# Altium schematic sheet TKZDB.SchDoc
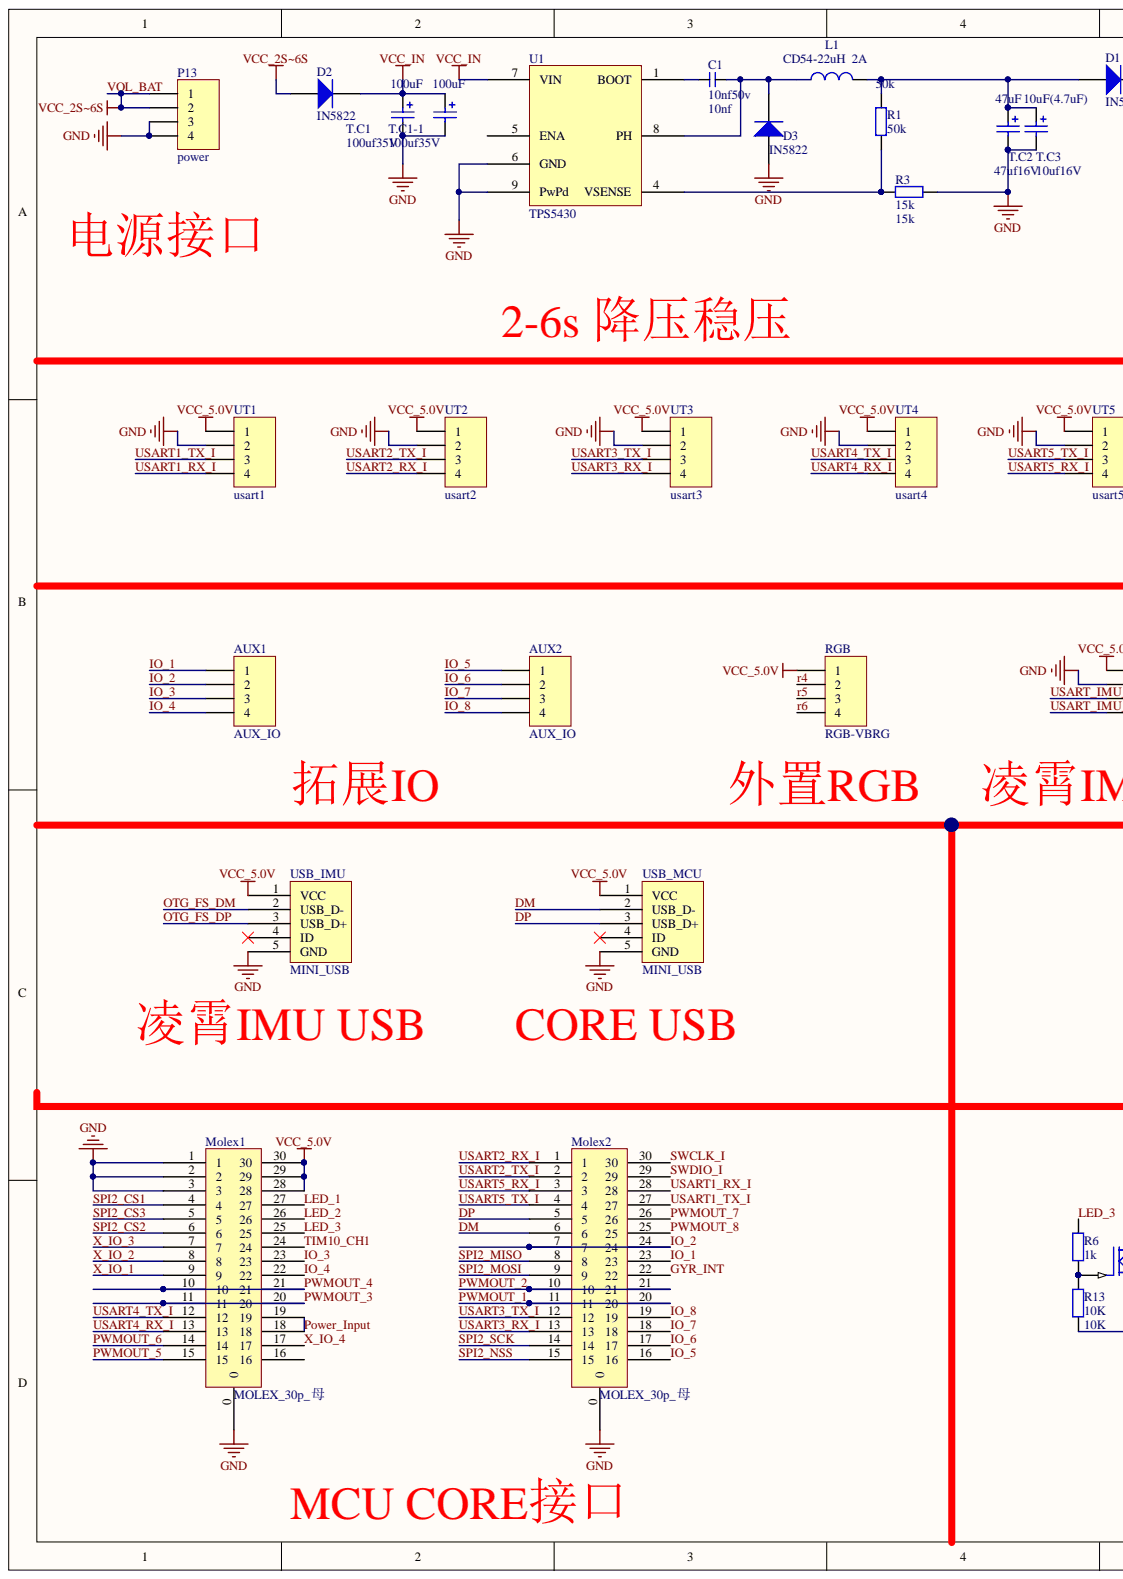
[diagram p0: TKZDB.SchDoc, left]
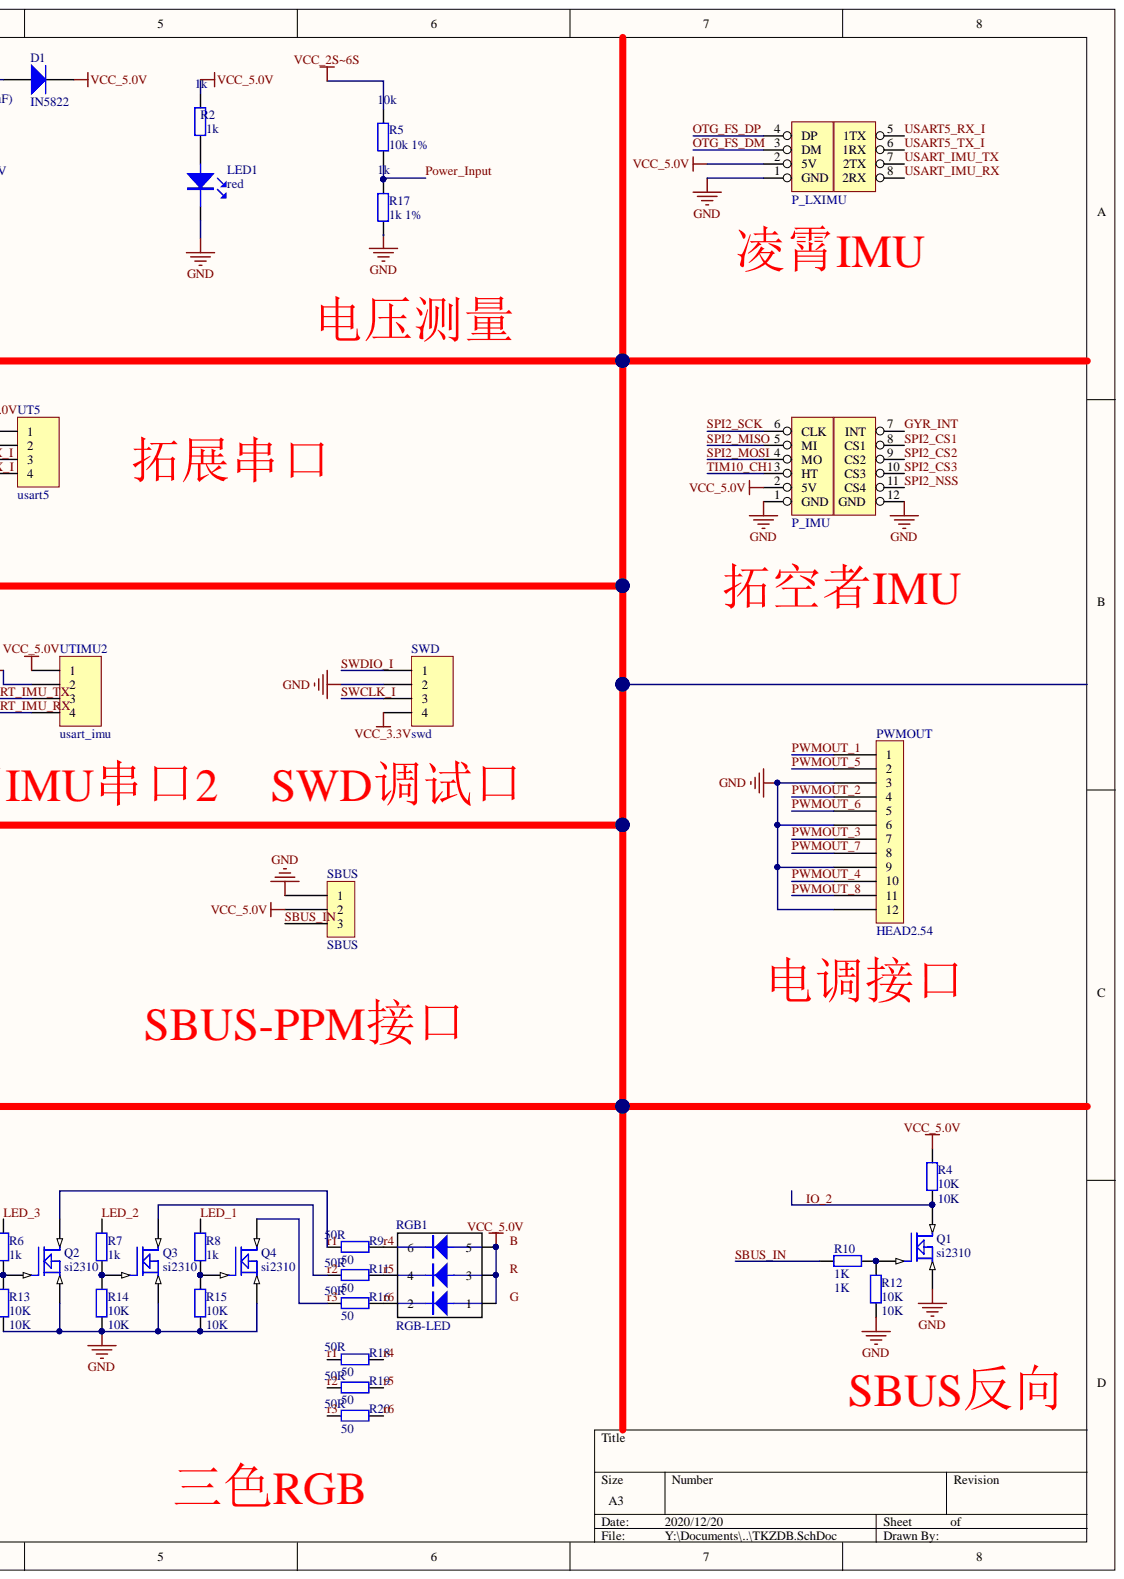
[diagram p1: TKZDB.SchDoc, right]

## Parts
AUX1  AUX_IO  [SH_1.0_卧]
AUX2  AUX_IO  [SH_1.0_卧]
C1  10nf50v  value 10nf  [0402_small]
D1  IN5822  [SOD123]
D2  IN5822  [SOD123]
D3  IN5822  [SOD123]
L1  CD54‐22uH  2A  [L-8X7mm-S]
LED1  red  [0402_small]
Molex1  MOLEX_30p_母  [MOLEX_30p_母]
Molex2  MOLEX_30p_母  [MOLEX_30p_母]
P13  power  [SH_1.0_卧]
P_IMU  IMU_TKZ  [IMU_TKZ_SM2]  (value not drawn)
P_LXIMU  IMU_LX  [IMU-LX-SM2]  (value not drawn)
PWMOUT  HEAD2.54  [HEAD1*3*4W]
Q1  si2310  [SOT-23]
Q2  si2310  [SOT-23]
Q3  si2310  [SOT-23]
Q4  si2310  [SOT-23]
R1  50k  [0402_small]
R2  1k  [0402_small]
R3  15k  [0402_small]
R4  10K  [0402_small]
R5  10k 1%  value 10k  [0402_small]
R6  1k  [0402_small]
R7  1k  [0402_small]
R8  1k  [0402_small]
R9  50  value 50R  [0402_small]
R10  1K  [0402_small]
R11  50  value 50R  [0402_small]
R12  10K  [0402_small]
R13  10K  [0402_small]
R14  10K  [0402_small]
R15  10K  [0402_small]
R16  50  value 50R  [0402_small]
R17  1k 1%  value 1k  [0402_small]
R18  50  value 50R  [0402_small]
R19  50  value 50R  [0402_small]
R20  50  value 50R  [0402_small]
RGB  RGB-VBRG  [SH_1.0_卧]
RGB1  RGB-LED  [zhuixin_5050RGB]
SBUS  SBUS  [SH_1.0_3P卧]
SWD  swd  [SH_1.0_卧]
T.C1  100uf35V  value 100uF  [TAN-C(6032-28)]
T.C1-1  100uf35V  value 100uF  [TAN-C(6032-28)]
T.C2  47uf16V  value 47uF  [TAN-B(3528-21)]
T.C3  10uf16V  value 10uF(4.7uF)  [TAN-A(3216-18)]
U1  TPS5430  [R-PDSO-G8]
USB_IMU  MINI_USB  [MICROUSB2]
USB_MCU  MINI_USB  [MICROUSB2]
UT1  usart1  [SH_1.0_卧]
UT2  usart2  [SH_1.0_卧]
UT3  usart3  [SH_1.0_卧]
UT4  usart4  [SH_1.0_卧]
UT5  usart5  [SH_1.0_卧]
UTIMU2  usart_imu  [SH_1.0_卧]

## Nets
GND: D3.1 LED1.2 Molex1.0 Molex1.1 Molex1.2 Molex1.3 Molex2.0 P13.3 P13.4 P_IMU.1(GND) P_IMU.12(GND) P_LXIMU.1(GND) PWMOUT.3 PWMOUT.6 PWMOUT.9 PWMOUT.12 Q1.2 Q2.2 Q3.2 Q4.2 R3.2 R12.1 R13.1 R14.1 R15.1 R17.1 SBUS.1 SWD.2 T.C1.2 T.C1-1.2 T.C2.2 T.C3.2 U1.6(GND) U1.9(PwPd) USB_IMU.5(GND) USB_MCU.5(GND) UT1.2 UT2.2 UT3.2 UT4.2 UT5.2 UTIMU2.2
VCC_3.3V: SWD.4
VCC_5.0V: D1.2 Molex1.28 Molex1.29 Molex1.30 P_IMU.2(5V) P_LXIMU.2(5V) R2.2 R4.2 RGB.1 RGB1.1 RGB1.3 RGB1.5 SBUS.2 USB_IMU.1(VCC) USB_MCU.1(VCC) UT1.1 UT2.1 UT3.1 UT4.1 UT5.1 UTIMU2.1
VCC_IN: D2.2 T.C1.1 T.C1-1.1 U1.7(VIN)
DM: Molex2.6 USB_MCU.2(USB_D-)
DP: Molex2.5 USB_MCU.3(USB_D+)
GYR_INT: Molex2.22 P_IMU.7(INT)
IO_1: AUX1.1 Molex2.23
IO_2: AUX1.2 Molex2.7 Molex2.24 Q1.3 R4.1
IO_3: AUX1.3 Molex1.23
IO_4: AUX1.4 Molex1.22
IO_5: AUX2.1 Molex2.16
IO_6: AUX2.2 Molex2.17
IO_7: AUX2.3 Molex2.18
IO_8: AUX2.4 Molex2.19
LED_1: Molex1.27 R8.1
LED_2: Molex1.26 R7.1
LED_3: Molex1.25 R6.2
OTG_FS_DM: P_LXIMU.3(DM) USB_IMU.2(USB_D-)
OTG_FS_DP: P_LXIMU.4(DP) USB_IMU.3(USB_D+)
Power_Input: Molex1.18 Molex1.19 R5.1 R17.2
PWMOUT_1: Molex2.11 Molex2.20 PWMOUT.1
PWMOUT_2: Molex2.10 Molex2.21 PWMOUT.4
PWMOUT_3: Molex1.11 Molex1.20 PWMOUT.7
PWMOUT_4: Molex1.10 Molex1.21 PWMOUT.10
PWMOUT_5: Molex1.15 PWMOUT.2
PWMOUT_6: Molex1.14 PWMOUT.5
PWMOUT_7: Molex2.26 PWMOUT.8
PWMOUT_8: Molex2.25 PWMOUT.11
r1: Q2.3 R9.2 R18.2
r2: Q3.3 R11.2 R19.2
r3: Q4.3 R16.2 R20.2
r4: R9.1 R18.1 RGB.2 RGB1.6
r5: R11.1 R19.1 RGB.3 RGB1.4
r6: R16.1 R20.1 RGB.4 RGB1.2
SBUS_IN: R10.1 SBUS.3
SPI2_CS1: Molex1.4 P_IMU.8(CS1)
SPI2_CS2: Molex1.6 P_IMU.9(CS2)
SPI2_CS3: Molex1.5 P_IMU.10(CS3)
SPI2_MISO: Molex2.8 P_IMU.5(MI)
SPI2_MOSI: Molex2.9 P_IMU.4(MO)
SPI2_NSS: Molex2.15 P_IMU.11(CS4)
SPI2_SCK: Molex2.14 P_IMU.6(CLK)
SWCLK_I: Molex2.30 SWD.3
SWDIO_I: Molex2.29 SWD.1
TIM10_CH1: Molex1.24 P_IMU.3(HT)
USART1_RX_I: Molex2.28 UT1.4
USART1_TX_I: Molex2.27 UT1.3
USART2_RX_I: Molex2.1 UT2.4
USART2_TX_I: Molex2.2 UT2.3
USART3_RX_I: Molex2.13 UT3.4
USART3_TX_I: Molex2.12 UT3.3
USART4_RX_I: Molex1.13 UT4.4
USART4_TX_I: Molex1.12 UT4.3
USART5_RX_I: Molex2.3 P_LXIMU.5(1TX) UT5.4
USART5_TX_I: Molex2.4 P_LXIMU.6(1RX) UT5.3
USART_IMU_RX: P_LXIMU.8(2RX) UTIMU2.4
USART_IMU_TX: P_LXIMU.7(2TX) UTIMU2.3
VOL_BAT: D2.1 P13.1 P13.2 R5.2
X_IO_1: Molex1.9
X_IO_2: Molex1.8
X_IO_3: Molex1.7
X_IO_4: Molex1.17
NetC1_1: C1.1 D3.2 L1.2 U1.8(PH)
NetC1_2: C1.2 U1.1(BOOT)
NetD1_1: D1.1 L1.1 R1.1 T.C2.1 T.C3.1
NetLED1_1: LED1.1 R2.1
NetQ1_1: Q1.1 R10.2 R12.2
NetQ2_1: Q2.1 R6.1 R13.2
NetQ3_1: Q3.1 R7.2 R14.2
NetQ4_1: Q4.1 R8.2 R15.2
NetR1_2: R1.2 R3.1 U1.4(VSENSE)
B:
G:
N00000:
R:

Not connected: Molex1 16; U1 5(ENA); USB_IMU 4(ID); USB_MCU 4(ID)

## Library data of the parts
AUX1 AUX2 P13 RGB SWD UT1-UT5 UTIMU2 = Header 4: Header, 4-Pin; Component Kind: Standard; LatestRevisionDate: 17-Jul-2002; LatestRevisionNote: Re-released for DXP Platform.; Library Name: Miscellaneous Connectors.IntLib; Publisher: Altium Limited
C1 = CAP_0402: Component Kind: Standard; Library Name: My_lib_wjt.SchLib
D1-D3 = IN5822-SS34: 肖特基二极管; Component Kind: Standard; Library Name: Flyking 常用元件.SchLib
L1 = L: value: 22uH; Component Kind: Standard; Library Name: 常用元件库.SCHLIB
LED1 = D-LED: LED; Component Kind: Standard; Library Name: RCDQLY.SCHLIB
PWMOUT = HEAD1*3*4: Component Kind: Standard; Library Name: My_lib_wjt.SchLib
Q1-Q4 = MOSFET N: Component Kind: Standard; Library Name: 场效应管及可控硅.SCHLIB
R1-R20 = Res: Resistor; Component Kind: Standard; LatestRevisionDate: 17-Jul-2002; LatestRevisionNote: Re-released for DXP Platform.; PackageReference: AXIAL-0.4; Published: 8-Jun-2000; Simulation: RESISTOR; Library Name: SZG.SCHLIB; Publisher: Altium Limited
  R1: Value: 50k
  R2 R6-R8: Value: 1k
  R3: Value: 15k
  R4 R12-R15: Value: 10K
  R10: Value: 1K
SBUS = HEADER_3P: Component Kind: Standard; Library Name: My_lib_wjt.IntLib
T.C1 T.C1-1 T.C2 T.C3 = Cap TAN: TAN Capacitor (Radial); Component Kind: Standard; LatestRevisionDate: 17-Jul-2002; LatestRevisionNote: Re-released for DXP Platform.; Library Name: FCSchlib.SchLib; PackageReference: RB7.6-15; Published: 8-Jun-2000; Simulation: CAP; Publisher: Altium Limited
U1 = TPS5430: 9脚与地连接; Component Kind: Standard; Library Name: YJ.SchLib
Molex1 Molex2 = MOLEX_30p_母: Component Kind: Standard; Library Name: My_lib_wjt.SchLib
P_IMU = IMU_TKZ: Component Kind: Standard; Library Name: My_lib_wjt.SchLib
P_LXIMU = IMU_LX: Component Kind: Standard; Library Name: My_lib_wjt.SchLib
RGB1 = RGB-LED: Component Kind: Standard; Library Name: 原理.SchLib
USB_IMU USB_MCU = MINI_USB: Component Kind: Standard; Library Name: 6050.SchLib
